# Altium schematic sheet Power.SchDoc(Power)
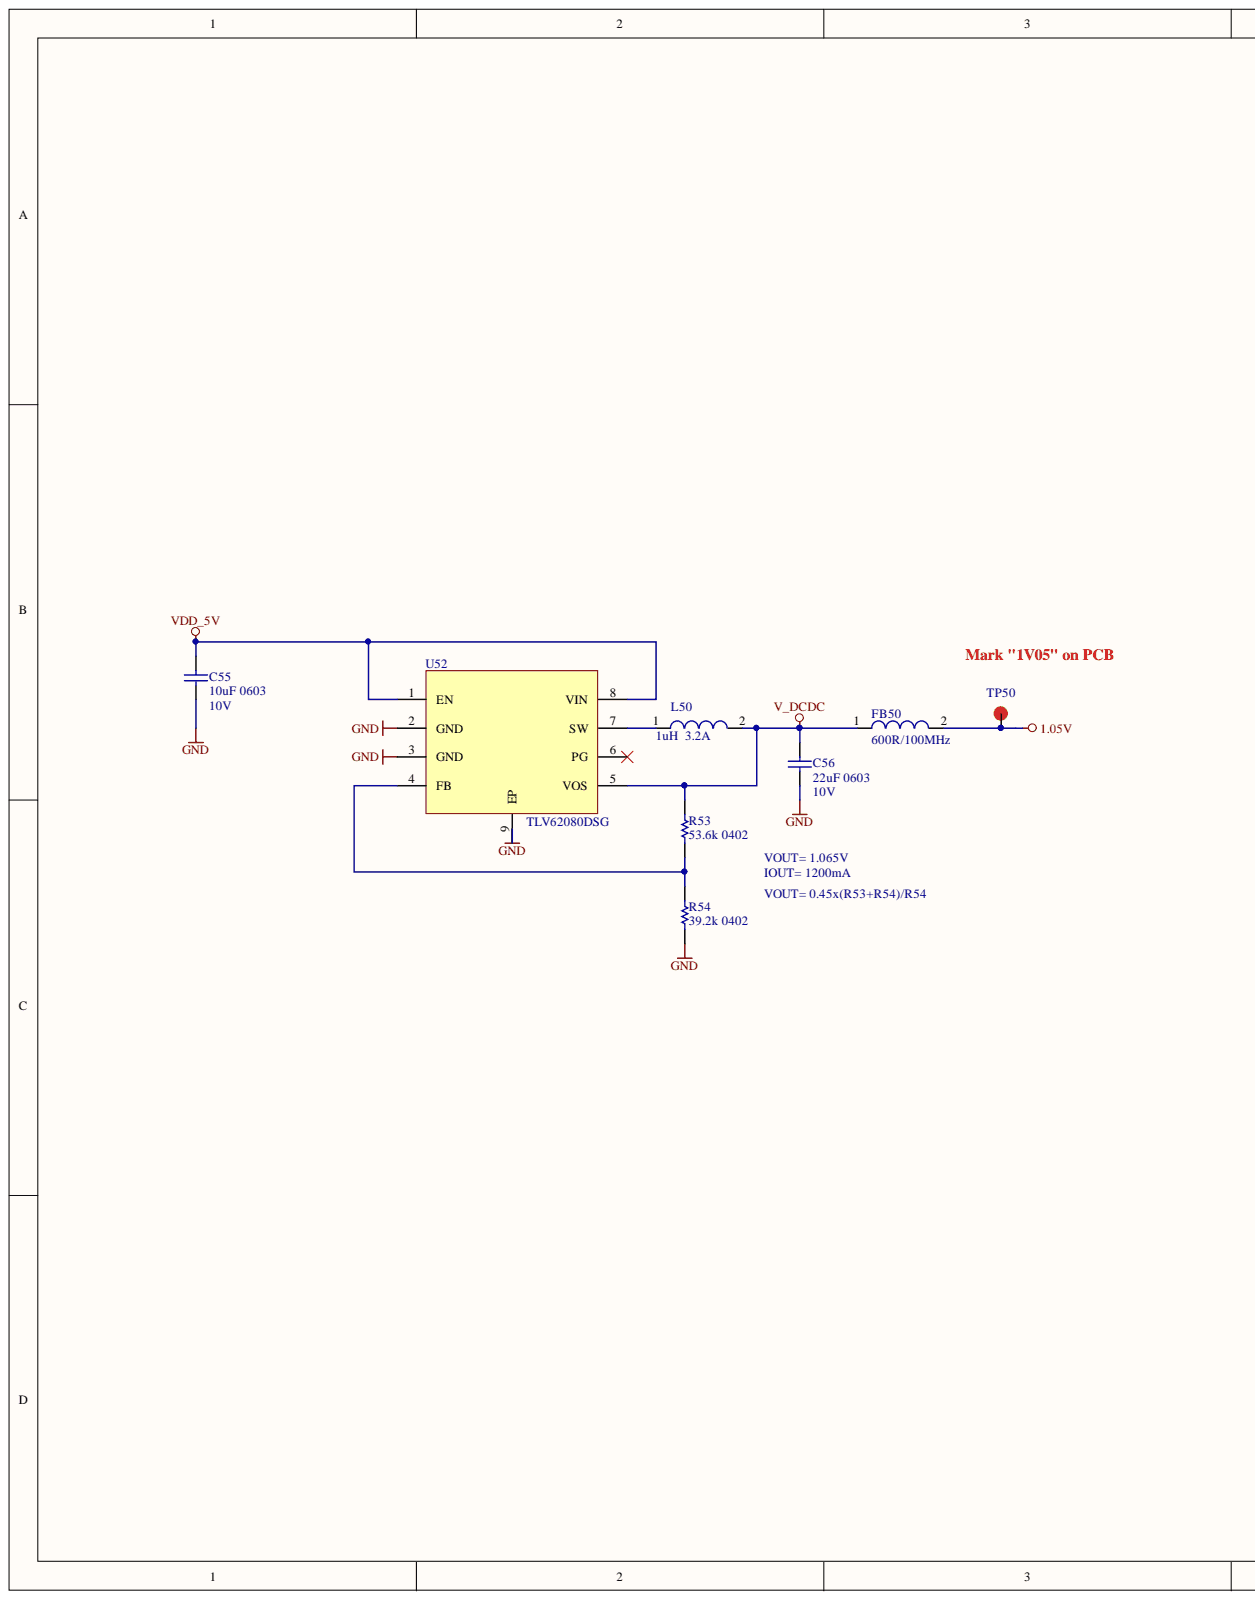
[diagram p0: Power.SchDoc, left]
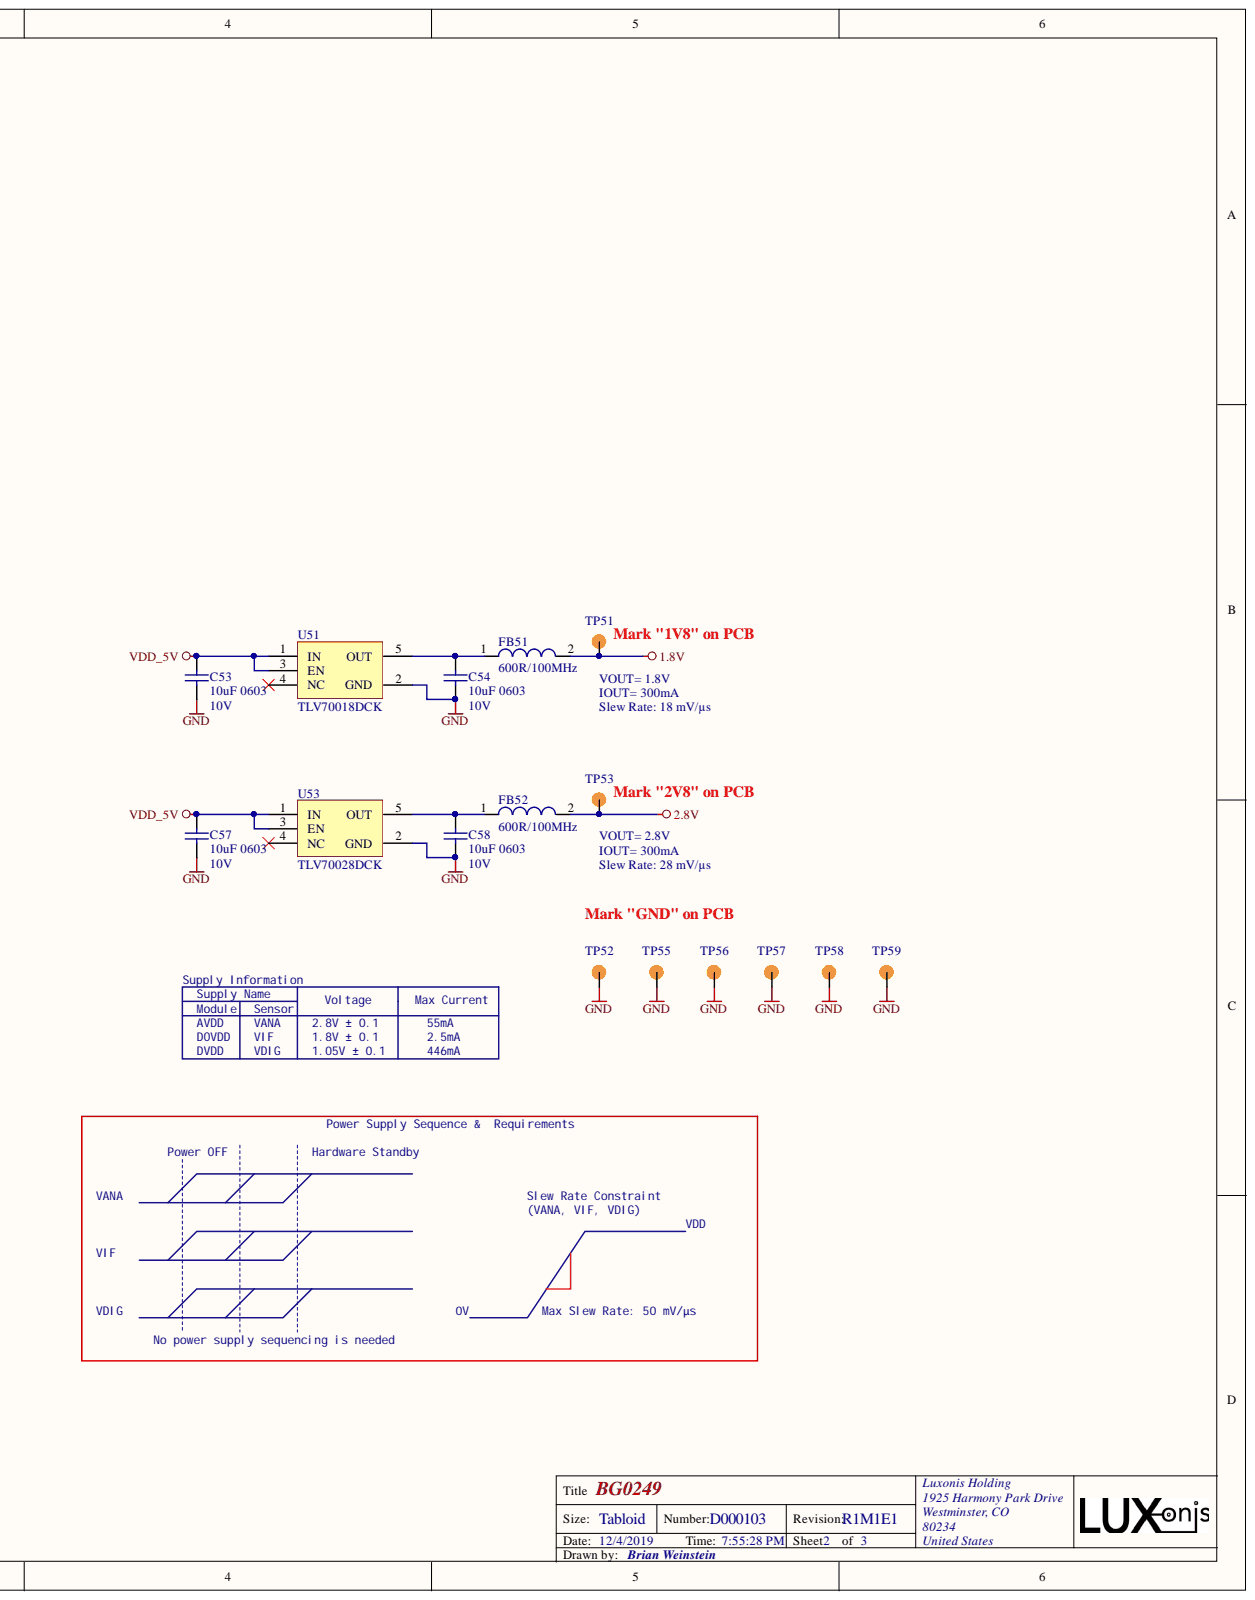
[diagram p1: Power.SchDoc, right]

## Parts
C53  10uF 0603  Voltage Rating (DC): 10V  Capacitance: 10uF  [CAPC0603(1608)100_N]
C54  10uF 0603  Voltage Rating (DC): 10V  Case Code (Imperial): 0603  Capacitance: 10uF  [CAPC0603(1608)100_N]
C55  10uF 0603  Voltage Rating (DC): 10V  Case Code (Imperial): 0603  Capacitance: 10uF  [CAPC0603(1608)100_N]
C56  22uF 0603  Voltage Rating (DC): 10V  Case Code (Imperial): 0603  Capacitance: 22uF  [CAPC0603(1608)100_N]
C57  10uF 0603  Voltage Rating (DC): 10V  Capacitance: 10uF  [CAPC0603(1608)100_N]
C58  10uF 0603  Voltage Rating (DC): 10V  Case Code (Imperial): 0603  Capacitance: 10uF  [CAPC0603(1608)100_N]
FB50  600R/100MHz  [2508056017Y2]
FB51  600R/100MHz  [2508056017Y2]
FB52  600R/100MHz  [2508056017Y2]
L50  Max DC Current: 3.2A  Inductance: 1uH  [DFE252012P-1R0M=P2]  (hidden comment: 1uH, 3.2A, 20%)
R53  53.6k 0402  Case Code (Imperial): 0402  [RESC0402(1005)_N]
R54  39.2k 0402  Case Code (Imperial): 0402  [RESC0402(1005)_N]
TP50  TP100_150_NS  [TP100_150_NS]  (value not drawn)  (no BOM)
TP51  TP100_150_NS  [TP100_150_NS]  (value not drawn)  (no BOM)
TP52  TP100_150_NS  [TP100_150_NS]  (value not drawn)  (no BOM)
TP53  TP100_150_NS  [TP100_150_NS]  (value not drawn)  (no BOM)
TP55  TP100_150_NS  [TP100_150_NS]  (value not drawn)  (no BOM)
TP56  TP100_150_NS  [TP100_150_NS]  (value not drawn)  (no BOM)
TP57  TP100_150_NS  [TP100_150_NS]  (value not drawn)  (no BOM)
TP58  TP100_150_NS  [TP100_150_NS]  (value not drawn)  (no BOM)
TP59  TP100_150_NS  [TP100_150_NS]  (value not drawn)  (no BOM)
U51  TLV70018DCK  [TLV70018DCK]
U52  TLV62080DSG  [TLV62080DSG]
U53  TLV70028DCK  [TLV70028DCK]

## Nets
1.05V: FB50.2 TP50.1
1.8V: FB51.2 TP51.1
2.8V: FB52.2 TP53.1
GND: C53.1 C54.1 C55.1 C56.1 C57.1 C58.1 R54.1 TP52.1 TP55.1 TP56.1 TP57.1 TP58.1 TP59.1 U51.2(GND) U52.2(GND) U52.3(GND) U52.9(EP) U53.2(GND)
V_DCDC: C56.2 FB50.1 L50.2 R53.2 U52.5(VOS)
VDD_5V: C53.2 C55.2 C57.2 U51.1(IN) U51.3(EN) U52.1(EN) U52.8(VIN) U53.1(IN) U53.3(EN)
NetC54_2: C54.2 FB51.1 U51.5(OUT)
NetC58_2: C58.2 FB52.1 U53.5(OUT)
NetL50_1: L50.1 U52.7(SW)
NetR53_1: R53.1 R54.2 U52.4(FB)

Not connected: U51 4(NC); U52 6(PG); U53 4(NC)

## Library data of the parts
C53-C55 C57 C58 = GRM188R61A106ME69D: CAP CER 10UF 10V X5R 0603 20%; Manufacturer 1: Murata; Material: Ceramic; Width: 0.8mm; Weight: 0.000222oz; Voltage Rating: 10V; Tolerance: 20%; Thickness: 0.035inch; Termination: SMD/SMT; Supplier Part Number 1: 490-10475-1-ND; Supplier 1: Digi-Key; Stock 1: 2873098; RoHS: Yes; REACH SVHC: Unknown; Pricing 1: 1=0.21000, 10=0.14100, 100=0.07130, 500=0.04876, 1000=0.04313 (USD); Packaging: Tape and Reel; Package Quantity: 4000; Mount: Surface Mount; Min Operating Temperature: -55°C; Max Operating Temperature: 85°C; Manufacturer Part Number 1: GRM188R61A106ME69D; Length: 1.6mm; Lead Free: Lead Free; Height: 0.8mm; Dielectric: X5R; Depth: 0.8mm; ComponentLink8URL: http://www.farnell.com/datasheets/2048014.pdf?src-supplier=Newark; ComponentLink8Description: http://www.farnell.com/datasheets/2048014.pdf?src-supplier=Newark; ComponentLink7URL: http://www.farnell.com/datasheets/2048014.pdf?src-supplier=Element14; ComponentLink7Description: http://www.farnell.com/datasheets/2048014.pdf?src-supplier=Element14; ComponentLink6URL: http://www.farnell.com/datasheets/1872210.pdf?src-supplier=Newark; ComponentLink6Description: http://www.farnell.com/datasheets/1872210.pdf?src-supplier=Newark; ComponentLink5URL: http://search.murata.co.jp/Ceramy/image/img/A01X/G101/ENG/GRM188R61A106ME69-01.pdf?src-supplier=Digi-Key; ComponentLink5Description: http://search.murata.co.jp/Ceramy/image/img/A01X/G101/ENG/GRM188R61A106ME69-01.pdf?src-supplier=Digi-Key; ComponentLink4URL: http://www.farnell.com/datasheets/1872210.pdf?src-supplier=Farnell; ComponentLink4Description: http://www.farnell.com/datasheets/1872210.pdf?src-supplier=Farnell; ComponentLink3URL: http://www.farnell.com/datasheets/2048014.pdf?src-supplier=Farnell; ComponentLink3Description: http://www.farnell.com/datasheets/2048014.pdf?src-supplier=Farnell; ComponentLink2URL: http://components.arrow.com/part/dataview/0323_06119472?src-supplier=Arrow+Europe; ComponentLink2Description: http://components.arrow.com/part/dataview/0323_06119472?src-supplier=Arrow+Europe; ComponentLink1URL: http://www.mouser.com/ds/2/281/c02e-2905.pdf?src-supplier=Mouser; ComponentLink1Description: http://www.mouser.com/ds/2/281/c02e-2905.pdf?src-supplier=Mouser; Component Kind: Standard; Case Code (Metric): 1608; Case/Package: 0603; Library Name: LuxonisMaster.SchLib
  C53 C57: Case Code (Imperial): 0603
C56 = GRM188R61A226ME15D: CAP CER 22UF 10V X5R 0603 20%; Manufacturer 1: Murata; Material: Ceramic; Width: 0.8mm; Weight: 0.000222oz; Voltage Rating: 10V; Tolerance: 20%; Thickness: 0.039inch; Termination: SMD/SMT; Supplier Part Number 1: 490-10476-1-ND; Supplier 1: Digi-Key; Stock 1: 984716; RoHS: Yes; REACH SVHC: No SVHC; Pricing 1: 1=0.31000, 10=0.21500, 100=0.12160, 500=0.08584, 1000=0.07512 (USD); Packaging: Tape and Reel; Package Quantity: 4000; Mount: Surface Mount; Min Operating Temperature: -55°C; Max Operating Temperature: 85°C; Manufacturer Part Number 1: GRM188R61A226ME15D; Length: 1.6mm; Lead Free: Lead Free; Height: 0.8mm; Dielectric: X5R; Depth: 0.8mm; ComponentLink1URL: https://datasheet.ciiva.com/46444/1769893-46444975.pdf?src-supplier=Element14; ComponentLink1Description: https://datasheet.ciiva.com/46444/1769893-46444975.pdf?src-supplier=Element14; Component Kind: Standard; Case Code (Metric): 1608; Case/Package: 0603; Library Name: LuxonisMaster.SchLib
FB50-FB52 = 2508056017Y2: FERRITE BEAD, 0.1OHM, 2A, 0805, 600R/100MHz; Manufacturer 1: Fair-Rite; Tolerance: 25%; Test Frequency: 100MHz; Resistance: 350mR; Impedance: 600R; DC Resistance (DCR): 100mR; Width: 1.25mm; Termination: SMD/SMT; Supplier Part Number 1: 1934-1487-1-ND; Supplier 1: Digi-Key; Stock 1: 100491; RoHS: Yes; REACH SVHC: No SVHC; Radiation Hardening: No; Pricing 1: 1=0.10000, 10=0.07900, 25=0.07120, 50=0.05240, 100=0.04520, 250=0.03568, 500=0.03014, 1000=0.02538 (USD); Packaging: Tape and Reel; Package Quantity: 4000; Number of Pins: 2; Min Operating Temperature: -55°C; Military Standard: Not; Max Operating Temperature: 125°C; Max DC Current: 2A; Manufacturer Part Number 1: 2508056017Y2; Length: 2mm; Height: 0.9mm; Frequency: 100MHz; Depth: 1.25mm; DC Current: 2A; Current Rating: 2A; ComponentLink5URL: https://datasheet.ciiva.com/7620/26143-7620140.pdf?src-supplier=Element14; ComponentLink5Description: https://datasheet.ciiva.com/7620/26143-7620140.pdf?src-supplier=Element14; ComponentLink4URL: https://datasheet.ciiva.com/18090/getdatasheetpartid-212640-18090187.pdf?src-supplier=Verical; ComponentLink4Description: https://datasheet.ciiva.com/18090/getdatasheetpartid-212640-18090187.pdf?src-supplier=Verical; ComponentLink3URL: https://datasheet.ciiva.com/1127/26143-1127514.pdf?src-supplier=Farnell; ComponentLink3Description: https://datasheet.ciiva.com/1127/26143-1127514.pdf?src-supplier=Farnell; ComponentLink2URL: https://datasheet.ciiva.com/22735/652149-22735047.pdf?src-supplier=Element14; ComponentLink2Description: https://datasheet.ciiva.com/22735/652149-22735047.pdf?src-supplier=Element14; ComponentLink1URL: https://datasheet.ciiva.com/3854/3802508056017y2-3854245.pdf?src-supplier=Arrow; ComponentLink1Description: https://datasheet.ciiva.com/3854/3802508056017y2-3854245.pdf?src-supplier=Arrow; Component Kind: Standard; Case Code (Metric): 2012; Case Code (Imperial): 0805; Case/Package: 0805; Library Name: LuxonisMaster.SchLib
L50 = DFE252012P-1R0M=P2: FIXED IND 1UH 3.2A 42 MOHM SMD; Manufacturer 1: Murata; Test Frequency: 1MHz; Shielding: Shielded; DC Resistance (DCR): 42mR; Current Rating: 4.3A; Core Material: Ferrite; Width: 0.079inch; Tolerance: 20%; Supplier Part Number 4: 2530071; Supplier Part Number 3: 81-DFE252012P-1R0MP2; Supplier Part Number 2: 490-10647-6-ND; Supplier Part Number 1: 490-10647-1-ND; Supplier 4: Element14; Supplier 3: Mouser; Supplier 2: Digi-Key; Supplier 1: Digi-Key; Stock 4: 41; Stock 3: 667; Stock 2: 95; Stock 1: 2560; RoHS: Yes; Pricing 4: 1=0.64000, 10=0.56500, 100=0.40500, 500=0.34000, 1000=0.27500 (SGD); Pricing 3: 1=0.43000, 10=0.37900, 50=0.37900, 100=0.27000, 1000=0.18400, 10000=0.14600 (USD); Pricing 2: 1=0.43000, 10=0.37800, 100=0.27030, 500=0.22702, 1000=0.18377 (USD); Pricing 1: 1=0.43000, 10=0.37800, 100=0.27030, 500=0.22702, 1000=0.18377 (USD); Packaging: Tape and Reel; Mount: Surface Mount; Min Operating Temperature: -40°C; Max Operating Temperature: 125°C; Manufacturer Part Number 4: DFE252012P-1R0M=P2; Manufacturer Part Number 3: DFE252012P-1R0M=P2; Manufacturer Part Number 2: DFE252012P-1R0M=P2; Manufacturer Part Number 1: DFE252012P-1R0M=P2; Manufacturer Part Number: DFE252012P-1R0M=P2; Manufacturer 4: Murata; Manufacturer 3: Murata; Manufacturer 2: Murata; Manufacturer: Murata; Length: 0.098inch; Height - Seated (Max): 0.039inch; Depth: 2mm; Composition: Wirewound; ComponentLink4URL: http://www.toko.co.jp/products/pdf/inductors/dfe252012p.pdf?src-supplier=Avnet; ComponentLink4Description: http://www.toko.co.jp/products/pdf/inductors/dfe252012p.pdf?src-supplier=Avnet; ComponentLink3URL: http://www.murata.com/~/media/webrenewal/products/inductor/chip/tokoproducts/wirewoundmetalalloychiptype/m_dfe252012p.ashx?src-supplier=Digi-Key; ComponentLink3Description: http://www.murata.com/~/media/webrenewal/products/inductor/chip/tokoproducts/wirewoundmetalalloychiptype/m_dfe252012p.ashx?src-supplier=Digi-Key; ComponentLink2URL: https://www.murata.com/~/media/webrenewal/products/inductor/chip/tokoproducts/wirewoundmetalalloychiptype/m_dfe252012p.ashx?src-supplier=Digi-Key; ComponentLink2Description: https://www.murata.com/~/media/webrenewal/products/inductor/chip/tokoproducts/wirewoundmetalalloychiptype/m_dfe252012p.ashx?src-supplier=Digi-Key; ComponentLink1URL: http://www.farnell.com/datasheets/2550134.pdf?src-supplier=Farnell; ComponentLink1Description: http://www.farnell.com/datasheets/2550134.pdf?src-supplier=Farnell; Component Kind: Standard; Case Code (Metric): 2520; Case Code (Imperial): 1008; Case/Package: 1008; Library Name: LuxonisMaster.SchLib
R53 = CRCW040253K6FKED: RES SMD 53.6K OHM 1% 1/16W 0402; Manufacturer 1: Vishay; Voltage Rating: 50V; Temperature Coefficient: 100ppm/°C; Resistance: 53.6kR; Power Rating: 63mW; Width: 0.5mm; Weight: 2.3E-05oz; Tolerance: 1%; Supplier Part Number 1: 541-53.6KLCT-ND; Supplier 1: Digi-Key; Stock 1: 82953; RoHS: Yes; REACH SVHC: Unknown; Radiation Hardening: No; Pricing 1: 1=0.10000, 10=0.03800, 100=0.01540, 1000=0.00690, 2500=0.00599, 5000=0.00495 (USD); Packaging: Tape and Reel; Package Quantity: 10; Number of Terminations: 2; Number of Pins: 2; Min Operating Temperature: -55°C; Military Standard: Not; Max Power Dissipation: 63mW; Max Operating Temperature: 155°C; Manufacturer Part Number 1: CRCW040253K6FKED; Length: 1mm; Height: 0.016inch; Features: Automotive AEC-Q200; Depth: 0.5mm; Contact Plating: Tin; Composition: Thick Film; ComponentLink9URL: https://datasheet.ciiva.com/3256/dcrcwe3-3256639.pdf?src-supplier=Avnet; ComponentLink9Description: https://datasheet.ciiva.com/3256/dcrcwe3-3256639.pdf?src-supplier=Avnet; ComponentLink8URL: https://datasheet.ciiva.com/2464/dcrcwe3-2464095.pdf?src-supplier=Digi-Key; ComponentLink8Description: https://datasheet.ciiva.com/2464/dcrcwe3-2464095.pdf?src-supplier=Digi-Key; ComponentLink7URL: https://datasheet.ciiva.com/3256/dcrcwe3-3256638.pdf?src-supplier=Avnet; ComponentLink7Description: https://datasheet.ciiva.com/3256/dcrcwe3-3256638.pdf?src-supplier=Avnet; ComponentLink6URL: https://datasheet.ciiva.com/2464/dcrcwe3-2464094.pdf?src-supplier=Digi-Key; ComponentLink6Description: https://datasheet.ciiva.com/2464/dcrcwe3-2464094.pdf?src-supplier=Digi-Key; ComponentLink5URL: https://datasheet.ciiva.com/2464/dcrcwe3-2464093.pdf?src-supplier=Digi-Key; ComponentLink5Description: https://datasheet.ciiva.com/2464/dcrcwe3-2464093.pdf?src-supplier=Digi-Key; ComponentLink4URL: https://datasheet.ciiva.com/16208/dcrcwe3-16208556.pdf?src-supplier=Future+Electronics; ComponentLink4Description: https://datasheet.ciiva.com/16208/dcrcwe3-16208556.pdf?src-supplier=Future+Electronics; ComponentLink3URL: https://datasheet.ciiva.com/7815/1697337-7815993.pdf?src-supplier=Element14; ComponentLink3Description: https://datasheet.ciiva.com/7815/1697337-7815993.pdf?src-supplier=Element14; ComponentLink2URL: https://datasheet.ciiva.com/7815/1697337-7815992.pdf?src-supplier=Element14; ComponentLink2Description: https://datasheet.ciiva.com/7815/1697337-7815992.pdf?src-supplier=Element14; ComponentLink1URL: https://datasheet.ciiva.com/5542/dcrcwe3-109170-5542453.pdf?src-supplier=Mouser; ComponentLink1Description: https://datasheet.ciiva.com/5542/dcrcwe3-109170-5542453.pdf?src-supplier=Mouser; Component Kind: Standard; Case Code (Metric): 1005; Case/Package: 0402; Library Name: LuxonisMaster.SchLib
R54 = CRCW040239K2FKED: RES SMD 39.2K OHM 1% 1/16W 0402; Manufacturer 1: Vishay; Voltage Rating (DC): 50V; Temperature Coefficient: 100ppm/°C; Resistance: 39.2kR; Power Rating: 63mW; Width: 0.5mm; Weight: 2.3E-05oz; Voltage Rating: 50V; Tolerance: 1%; Termination: SMD/SMT; Supplier Part Number 1: 541-39.2KLCT-ND; Supplier 1: Digi-Key; Stock 1: 46258; RoHS: Yes; REACH SVHC: Unknown; Radiation Hardening: No; Pricing 1: 1=0.10000, 10=0.03800, 100=0.01540, 1000=0.00690, 2500=0.00599, 5000=0.00495 (USD); Packaging: Tape and Reel; Package Quantity: 10; Number of Terminations: 2; Number of Pins: 2; Min Operating Temperature: -55°C; Military Standard: Not; Max Power Dissipation: 63mW; Max Operating Temperature: 155°C; Manufacturer Part Number 1: CRCW040239K2FKED; Length: 1mm; Lead Free: Lead Free; Height: 0.35mm; Features: Automotive AEC-Q200; Depth: 0.5mm; Contact Plating: Tin; Composition: Thick Film; ComponentLink11URL: https://datasheet.ciiva.com/7671/1533075-7671772.pdf?src-supplier=Element14; ComponentLink11Description: https://datasheet.ciiva.com/7671/1533075-7671772.pdf?src-supplier=Element14; ComponentLink10URL: https://datasheet.ciiva.com/7671/1697337-7671596.pdf?src-supplier=Element14; ComponentLink10Description: https://datasheet.ciiva.com/7671/1697337-7671596.pdf?src-supplier=Element14; ComponentLink9URL: https://datasheet.ciiva.com/16429/dcrcwe3-16429962.pdf?src-supplier=Future+Electronics; ComponentLink9Description: https://datasheet.ciiva.com/16429/dcrcwe3-16429962.pdf?src-supplier=Future+Electronics; ComponentLink8URL: https://datasheet.ciiva.com/22869/70201119-22869593.pdf?src-supplier=Allied+Electronics; ComponentLink8Description: https://datasheet.ciiva.com/22869/70201119-22869593.pdf?src-supplier=Allied+Electronics; ComponentLink7URL: https://datasheet.ciiva.com/16774/70201119-16774809.pdf?src-supplier=Allied+Electronics; ComponentLink7Description: https://datasheet.ciiva.com/16774/70201119-16774809.pdf?src-supplier=Allied+Electronics; ComponentLink6URL: https://datasheet.ciiva.com/2463/dcrcwe3-2463560.pdf?src-supplier=Digi-Key; ComponentLink6Description: https://datasheet.ciiva.com/2463/dcrcwe3-2463560.pdf?src-supplier=Digi-Key; ComponentLink5URL: https://datasheet.ciiva.com/2463/dcrcwe3-2463559.pdf?src-supplier=Digi-Key; ComponentLink5Description: https://datasheet.ciiva.com/2463/dcrcwe3-2463559.pdf?src-supplier=Digi-Key; ComponentLink4URL: https://datasheet.ciiva.com/3256/dcrcwe3-3256469.pdf?src-supplier=Avnet; ComponentLink4Description: https://datasheet.ciiva.com/3256/dcrcwe3-3256469.pdf?src-supplier=Avnet; ComponentLink3URL: https://datasheet.ciiva.com/2463/dcrcwe3-2463561.pdf?src-supplier=Digi-Key; ComponentLink3Description: https://datasheet.ciiva.com/2463/dcrcwe3-2463561.pdf?src-supplier=Digi-Key; ComponentLink2URL: https://datasheet.ciiva.com/16882/dcrcwe3-16882152.pdf?src-supplier=Avnet+Europe; ComponentLink2Description: https://datasheet.ciiva.com/16882/dcrcwe3-16882152.pdf?src-supplier=Avnet+Europe; ComponentLink1URL: https://datasheet.ciiva.com/11818/0900766b80d98824-11818068.pdf?src-supplier=RSComponents; ComponentLink1Description: https://datasheet.ciiva.com/11818/0900766b80d98824-11818068.pdf?src-supplier=RSComponents; Component Kind: Standard; Case Code (Metric): 1005; Case/Package: 0402; Library Name: LuxonisMaster.SchLib
TP50-TP53 TP55-TP59 = TEST_POINT: Library Name: LuxonisMaster.SchLib
U51 = TLV70018DCK: IC REG LINEAR 1.8V 200MA SC70-5 Linear Voltage Regulator IC  1 Output  200mA SC-70-5; Manufacturer Part Number 1: TLV70018DCKR; Manufacturer 1: Texas Instruments; Quiescent Current: 30uA; Power Rating: 320mW; Polarity: Positive; Output Voltage Accuracy: 2%; Output Type: Fixed; Number of Regulators: 1; Nominal Supply Current: 31uA; Min Input Voltage: 2V; Min Current Limit: 220mA; Max Input Voltage: 5.5V; Dropout Voltage: 175mV; Width: 1.4mm; Supplier Part Number 2: 296-25269-1-ND; Supplier Part Number 1: 296-32409-1-ND; Supplier 2: Digi-Key; Supplier 1: Digi-Key; Stock 2: 13188; Stock 1: 63905; RoHS: Yes; REACH SVHC: No SVHC; Radiation Hardening: No; Pricing 2: 1=0.55000, 10=0.46700, 100=0.34900 (USD); Pricing 1: 1=0.48000, 10=0.40900, 100=0.30570, 500=0.24020, 1000=0.18561 (USD); Power Dissipation: 320mW; Packaging: Tape and Reel; Package Quantity: 1; Output Voltage: 1.8V; Output Current: 200mA; Number of Pins: 5; Number of Outputs: 1; Nominal Output Voltage: 1.8V; Min Output Voltage: 1.8V; Min Operating Temperature: -40°C; Max Power Dissipation: 320mW; Max Output Voltage: 1.8V; Max Output Current: 200mA; Max Operating Temperature: 125°C; Manufacturer Part Number 2: TLV70018DCKT; Manufacturer 2: Texas Instruments; Length: 2.15mm; Lead Free: Lead Free; Height: 1mm; Contact Plating: Gold; ComponentLink4URL: https://datasheet.ciiva.com/7992/1882059-7992894.pdf?src-supplier=Element14; ComponentLink4Description: https://datasheet.ciiva.com/7992/1882059-7992894.pdf?src-supplier=Element14; ComponentLink3URL: https://datasheet.ciiva.com/14149/tlv700-eng-ds-14149837.pdf?src-supplier=Distrelec; ComponentLink3Description: https://datasheet.ciiva.com/14149/tlv700-eng-ds-14149837.pdf?src-supplier=Distrelec; ComponentLink2URL: https://datasheet.ciiva.com/22700/1882059-22700171.pdf?src-supplier=Element14; ComponentLink2Description: https://datasheet.ciiva.com/22700/1882059-22700171.pdf?src-supplier=Element14; ComponentLink1URL: https://datasheet.ciiva.com/41462/001014631-da-01-en-ic-reg-ldo-1-8v-0-tlv70018dckr-sot-5-tid-41462480.pdf?src-supplier=Conrad; ComponentLink1Description: https://datasheet.ciiva.com/41462/001014631-da-01-en-ic-reg-ldo-1-8v-0-tlv70018dckr-sot-5-tid-41462480.pdf?src-supplier=Conrad; Component Kind: Standard; Case/Package: SC; Accuracy: 2%; Library Name: LuxonisMaster.SchLib
U52 = TLV62080DSG: IC REG BUCK ADJ 1.2A SYNC 8WSON; Manufacturer Part Number 1: TLV62080DSGR; Manufacturer 1: Texas Instruments; Synchronous Rectifier: Yes; Switching Frequency: 2MHz; Quiescent Current: 30uA; Output Voltage: 4V; Output Type: Adjustable; Output Configuration: Positive; Number of Outputs: 1; Min Supply Voltage: 2.5V; Min Output Voltage: 500mV; Max Input Voltage: 5.5V; Supplier Part Number 2: 296-36759-1-ND; Supplier Part Number 1: 296-39270-1-ND; Supplier 2: Digi-Key; Supplier 1: Digi-Key; Stock 2: 6366; Stock 1: 93781; RoHS: Yes; REACH SVHC: No SVHC; Radiation Hardening: No; Pricing 2: 1=1.48000, 10=1.32700, 100=1.06620 (USD); Pricing 1: 1=1.35000, 10=1.20300, 100=0.93810, 500=0.77498, 1000=0.61182 (USD); Packaging: Tape and Reel; Package Quantity: 3000; Output Current: 1.2A; Number of Pins: 8; Nominal Supply Current: 30uA; Mount: Surface Mount; Min Operating Temperature: -40°C; Min Input Voltage: 2.5V; Max Output Voltage: 4V; Max Output Current: 1.2A; Max Operating Temperature: 125°C; Manufacturer Part Number 2: TLV62080DSGT; Manufacturer 2: Texas Instruments; Lead Free: Lead Free; Contact Plating: Gold; ComponentLink7URL: https://datasheet.ciiva.com/26104/tlv62080-26104460.pdf?src-supplier=Avnet+Europe; ComponentLink7Description: https://datasheet.ciiva.com/26104/tlv62080-26104460.pdf?src-supplier=Avnet+Europe; ComponentLink6URL: https://datasheet.ciiva.com/14378/tlv62080-14378283.pdf?src-supplier=Avnet; ComponentLink6Description: https://datasheet.ciiva.com/14378/tlv62080-14378283.pdf?src-supplier=Avnet; ComponentLink5URL: https://datasheet.ciiva.com/29732/tlv62080-29732151.pdf?src-supplier=Digi-Key; ComponentLink5Description: https://datasheet.ciiva.com/29732/tlv62080-29732151.pdf?src-supplier=Digi-Key; ComponentLink4URL: https://datasheet.ciiva.com/29732/tlv62080-29732156.pdf?src-supplier=Digi-Key; ComponentLink4Description: https://datasheet.ciiva.com/29732/tlv62080-29732156.pdf?src-supplier=Digi-Key; ComponentLink3URL: https://datasheet.ciiva.com/29732/tlv62080-29732147.pdf?src-supplier=Digi-Key; ComponentLink3Description: https://datasheet.ciiva.com/29732/tlv62080-29732147.pdf?src-supplier=Digi-Key; ComponentLink2URL: https://datasheet.ciiva.com/14378/tlv62080-14378282.pdf?src-supplier=Avnet; ComponentLink2Description: https://datasheet.ciiva.com/14378/tlv62080-14378282.pdf?src-supplier=Avnet; ComponentLink1URL: https://datasheet.ciiva.com/6604/tlv62080-6604087.pdf?src-supplier=Digi-Key; ComponentLink1Description: https://datasheet.ciiva.com/6604/tlv62080-6604087.pdf?src-supplier=Digi-Key; Component Kind: Standard; Library Name: LuxonisMaster.SchLib
U53 = TLV70028DCK: IC REG LINEAR 2.8V 200MA SC70-5 Linear Voltage Regulator IC  1 Output  200mA SC-70-5; Manufacturer Part Number 1: TLV70028DCKT; Manufacturer 1: Texas Instruments; Quiescent Current: 30uA; Power Rating: 320mW; Polarity: Positive; Output Voltage Accuracy: 2%; Output Type: Fixed; Number of Regulators: 1; Nominal Supply Current: 31uA; Min Supply Voltage: 2V; Min Current Limit: 220mA; Max Supply Voltage: 5.5V; Dropout Voltage: 175mV; Width: 1.4mm; Termination: SMD/SMT; Supplier Part Number 2: 296-39279-1-ND; Supplier Part Number 1: 296-25271-1-ND; Supplier 2: Digi-Key; Supplier 1: Digi-Key; Stock 2: 30013; Stock 1: 832; RoHS: Yes; REACH SVHC: No SVHC; Radiation Hardening: No; Pricing 2: 1=0.48000, 10=0.40900, 100=0.30570, 500=0.24020, 1000=0.18561 (USD); Pricing 1: 1=0.55000, 10=0.46700, 100=0.34900 (USD); Power Dissipation: 320mW; Packaging: Tape and Reel; Package Quantity: 1; Output Voltage: 2.8V; Output Current: 200mA; Number of Pins: 5; Number of Outputs: 1; Nominal Output Voltage: 2.8V; Min Output Voltage: 2.8V; Min Operating Temperature: -40°C; Min Input Voltage: 2V; Max Power Dissipation: 320mW; Max Output Voltage: 2.8V; Max Output Current: 200mA; Max Operating Temperature: 125°C; Max Input Voltage: 5.5V; Manufacturer Part Number 2: TLV70028DCKR; Manufacturer 2: Texas Instruments; Length: 2.15mm; Lead Free: Lead Free; Height: 1mm; Contact Plating: Gold; ComponentLink11URL: http://www.ti.com/lit/ds/slvsa00e/slvsa00e.pdf?src-supplier=Digi-Key; ComponentLink11Description: http://www.ti.com/lit/ds/slvsa00e/slvsa00e.pdf?src-supplier=Digi-Key; ComponentLink10URL: http://www.ti.com/litv/slvsa00d?src-supplier=Mouser; ComponentLink10Description: http://www.ti.com/litv/slvsa00d?src-supplier=Mouser; ComponentLink9URL: http://components.arrow.com/part/dataview/48329233S8322732N7713?src-supplier=Arrow; ComponentLink9Description: http://components.arrow.com/part/dataview/48329233S8322732N7713?src-supplier=Arrow; ComponentLink8URL: http://www.ti.com/general/docs/lit/getliterature.tsp?genericPartNumber=TLV700&&fileType=pdf&src-supplier=Avnet; ComponentLink8Description: http://www.ti.com/general/docs/lit/getliterature.tsp?genericPartNumber=TLV700&&fileType=pdf&src-supplier=Avnet; ComponentLink7URL: http://www.ti.com/general/docs/lit/getliterature.tsp?genericPartNumber=TLV700&&fileType=pdf&src-supplier=Avnet+Asia; ComponentLink7Description: http://www.ti.com/general/docs/lit/getliterature.tsp?genericPartNumber=TLV700&&fileType=pdf&src-supplier=Avnet+Asia; ComponentLink6URL: http://www.ti.com/lit/pdf/slyy050?src-supplier=Digi-Key; ComponentLink6Description: http://www.ti.com/lit/pdf/slyy050?src-supplier=Digi-Key; ComponentLink5URL: http://www.ti.com/lit/ds/slvsa00d/slvsa00d.pdf?src-supplier=Digi-Key; ComponentLink5Description: http://www.ti.com/lit/ds/slvsa00d/slvsa00d.pdf?src-supplier=Digi-Key; ComponentLink4URL: http://focus.ti.com/lit/ds/symlink/tlv70033.pdf?src-supplier=Avnet+Europe; ComponentLink4Description: http://focus.ti.com/lit/ds/symlink/tlv70033.pdf?src-supplier=Avnet+Europe; ComponentLink3URL: http://components.arrow.com/part/dataview/0323_00585634?src-supplier=Arrow+Europe; ComponentLink3Description: http://components.arrow.com/part/dataview/0323_00585634?src-supplier=Arrow+Europe; ComponentLink2URL: https://datasheet.ciiva.com/22700/1882059-22700172.pdf?src-supplier=Element14; ComponentLink2Description: https://datasheet.ciiva.com/22700/1882059-22700172.pdf?src-supplier=Element14; ComponentLink1URL: https://datasheet.ciiva.com/14149/tlv700-eng-ds-14149840.pdf?src-supplier=Distrelec; ComponentLink1Description: https://datasheet.ciiva.com/14149/tlv700-eng-ds-14149840.pdf?src-supplier=Distrelec; Component Kind: Standard; Case/Package: SC; Accuracy: 2%; Library Name: LuxonisMaster.SchLib
